# Altium schematic sheet FC20.SchDoc(FC20)
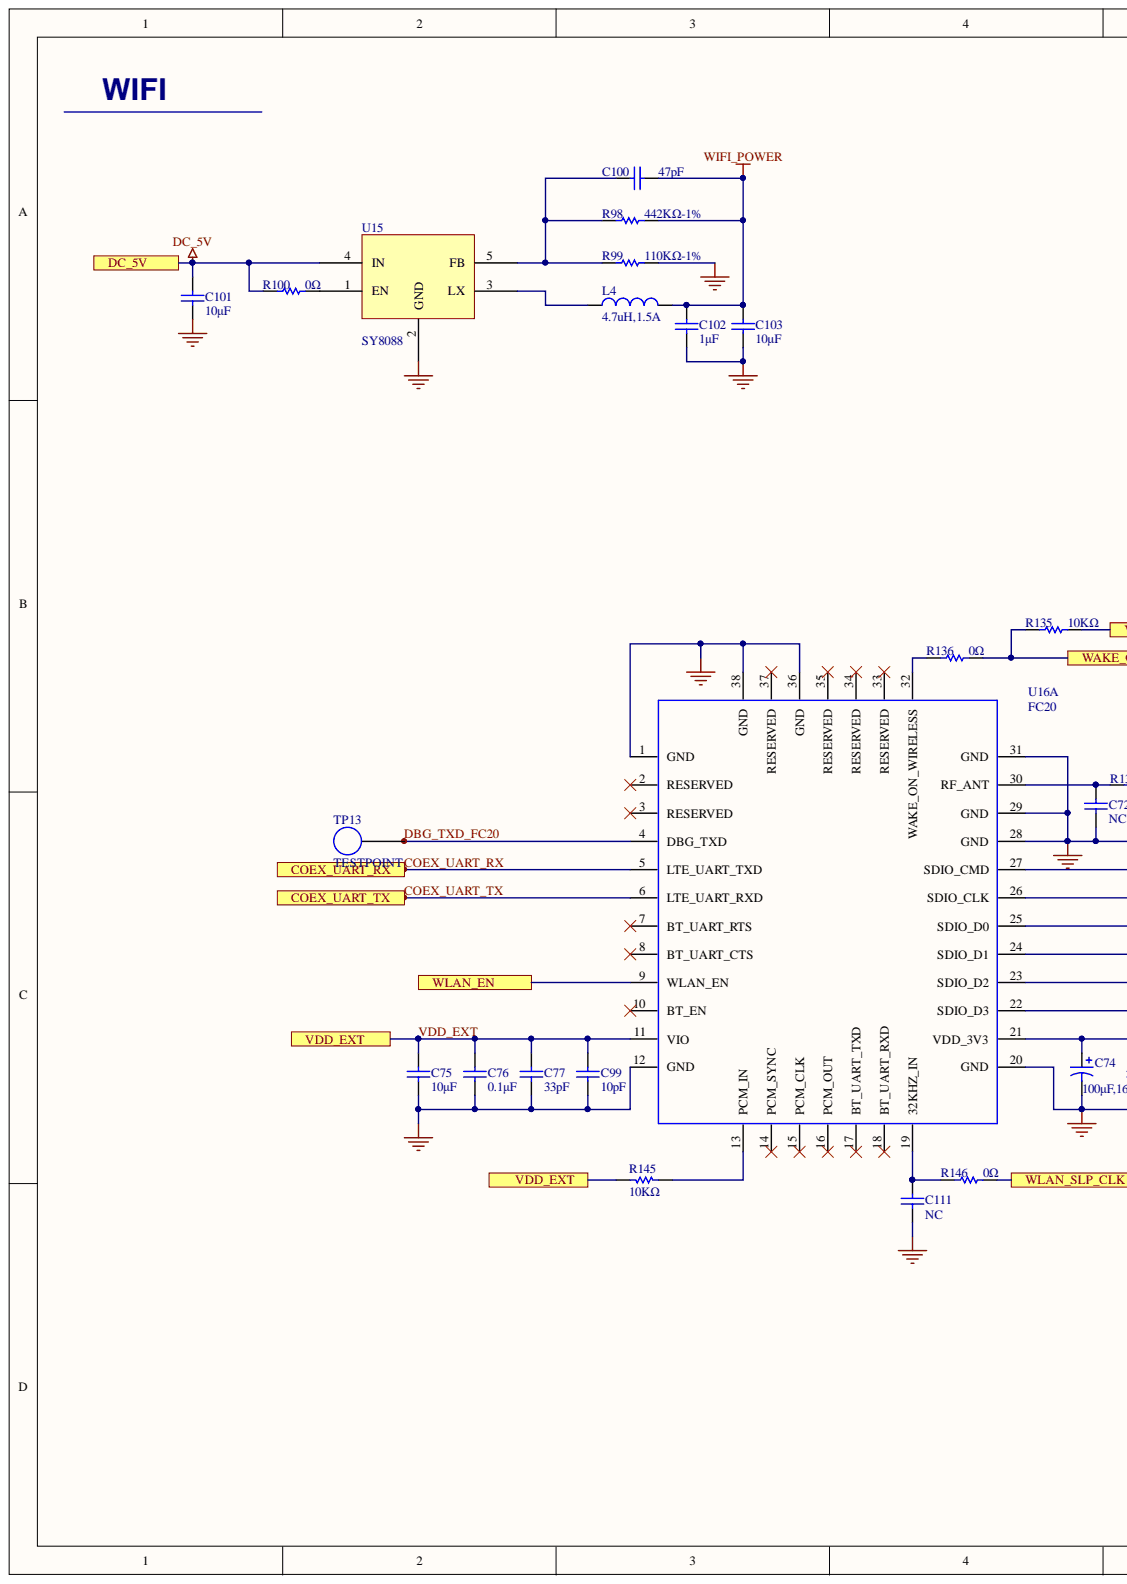
[diagram p0: FC20.SchDoc, left]
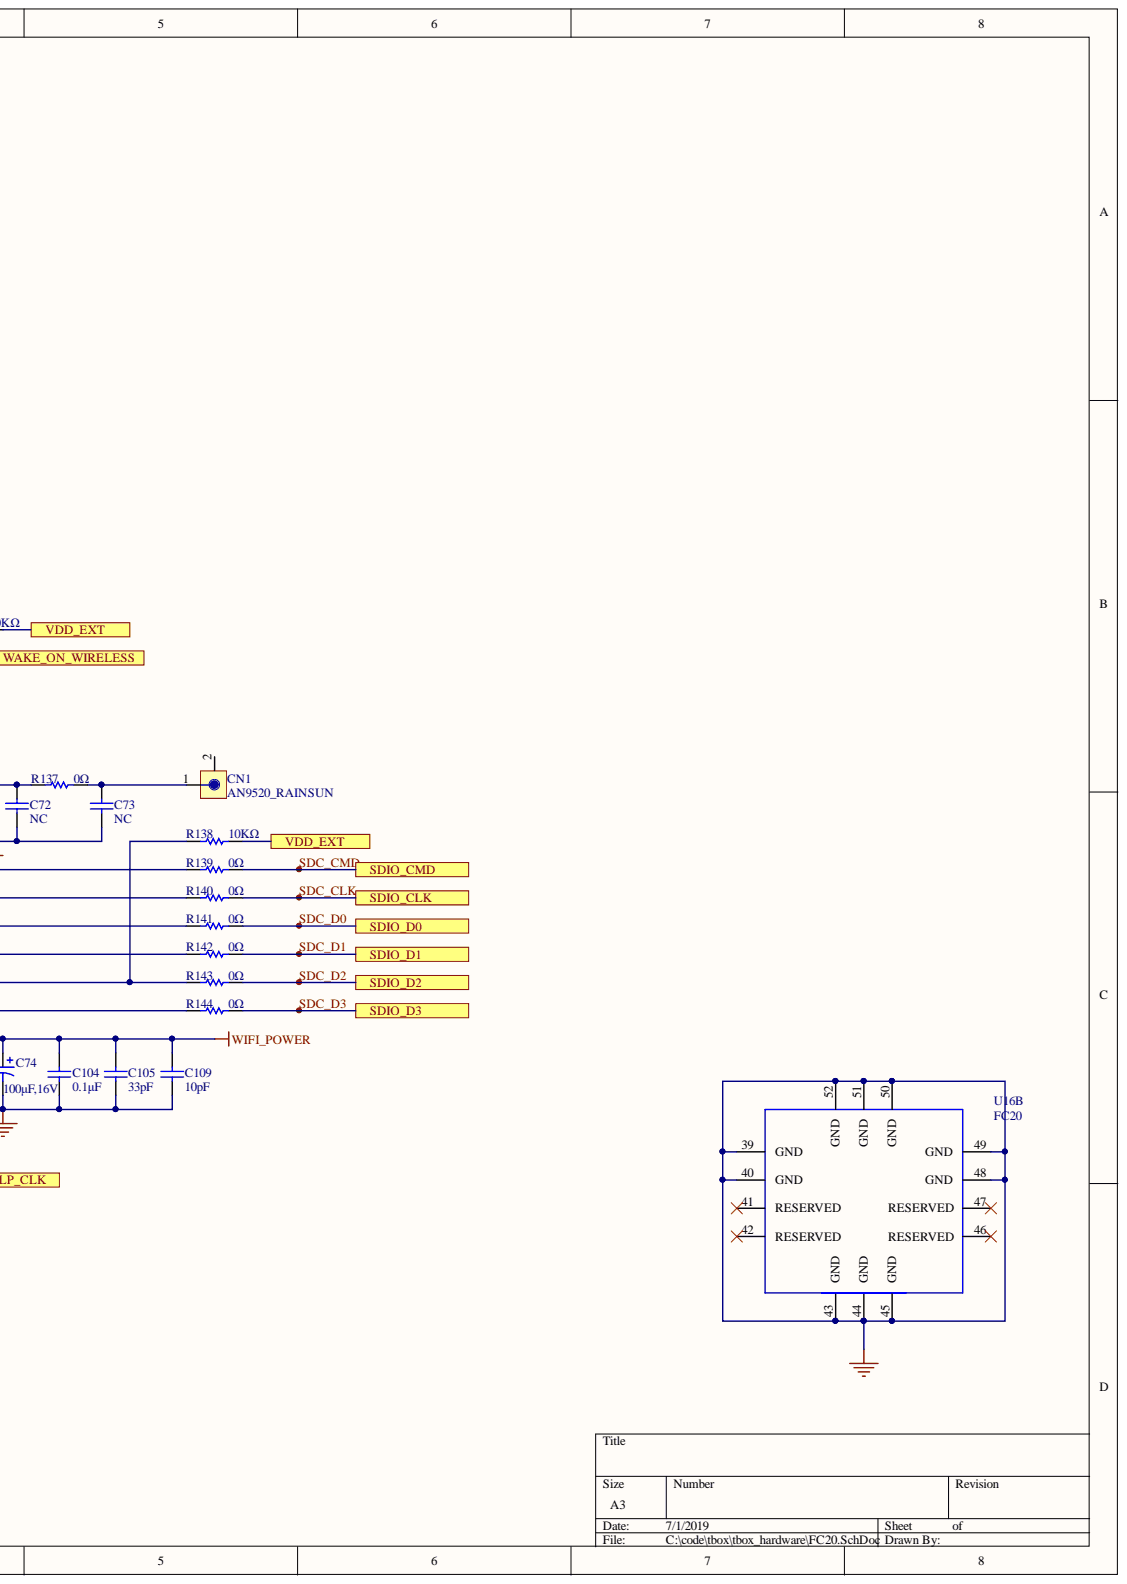
[diagram p1: FC20.SchDoc, right]

## Parts
C72  NC  [C0402]
C73  NC  [C0402]
C74  100μF,16V  [C1210]
C75  10μF  [C0805]
C76  0.1μF  [C0402]
C77  33pF  [C0402]
C99  10pF  [C0402]
C100  47pF  [C0402]
C101  10μF  [C0805]
C102  1μF  [C0402]
C103  10μF  [C0805]
C104  0.1μF  [C0402]
C105  33pF  [C0402]
C109  10pF  [C0402]
C111  NC  [C0402]
CN1  AN9520_RAINSUN  [ANT9mm*2mm]
L4  4.7uH,1.5A  [L-0420]
R98  442KΩ-1%  [R0402]
R99  110KΩ-1%  [R0402]
R100  0Ω  [R0402]
R135  10KΩ  [R0402]
R136  0Ω  [R0402]
R137  0Ω  [R0402]
R138  10KΩ  [R0402]
R139  0Ω  [R0402]
R140  0Ω  [R0402]
R141  0Ω  [R0402]
R142  0Ω  [R0402]
R143  0Ω  [R0402]
R144  0Ω  [R0402]
R145  10KΩ  [R0402]
R146  0Ω  [R0402]
TP13  TESTPOINT  [TP]
U15  SY8088  [SOT23-5]
U16  FC20  [FC20]  (parts A,B)

## Ports
COEX_UART_RX, COEX_UART_TX, DC_5V, SDIO_CLK, SDIO_CMD, SDIO_D0, SDIO_D1, SDIO_D2, SDIO_D3, VDD_EXT, WAKE_ON_WIRELESS, WLAN_EN, WLAN_SLP_CLK

## Nets
GND: C72.1 C73.1 C74.2 C75.1 C76.1 C77.1 C99.1 C101.1 C102.1 C103.1 C104.1 C105.1 C109.1 C111.1 R99.2 U15.2(GND) U16.1(GND) U16.12(GND) U16.20(GND) U16.28(GND) U16.29(GND) U16.31(GND) U16.36(GND) U16.38(GND) U16.39(GND) U16.40(GND) U16.43(GND) U16.44(GND) U16.45(GND) U16.48(GND) U16.49(GND) U16.50(GND) U16.51(GND) U16.52(GND)
WIFI_POWER: C74.1 C100.2 C102.2 C103.2 C104.2 C105.2 C109.2 L4.2 R98.2 U16.21(VDD_3V3)
COEX_UART_RX: U16.5(LTE_UART_TXD) ; port COEX_UART_RX
COEX_UART_TX: U16.6(LTE_UART_RXD) ; port COEX_UART_TX
DBG_TXD_FC20: TP13.1 U16.4(DBG_TXD)
DC_5V: C101.2 R100.1 U15.4(IN) ; port DC_5V
SDC_CLK: R140.1 ; port SDIO_CLK
SDC_CMD: R139.1 ; port SDIO_CMD
SDC_D0: R141.1 ; port SDIO_D0
SDC_D1: R142.1 ; port SDIO_D1
SDC_D2: R143.1 ; port SDIO_D2
SDC_D3: R144.1 ; port SDIO_D3
VDD_EXT: C75.2 C76.2 C77.2 C99.2 R135.1 R138.1 R145.1 U16.11(VIO) ; port VDD_EXT
WAKE_ON_WIRELESS: R135.2 R136.1 ; port WAKE_ON_WIRELESS
WLAN_EN: U16.9(WLAN_EN) ; port WLAN_EN
WLAN_SLP_CLK: R146.1 ; port WLAN_SLP_CLK
NetC72_2: C72.2 R137.2 U16.30(RF_ANT)
NetC73_2: C73.2 CN1.1 R137.1
NetC100_1: C100.1 R98.1 R99.1 U15.5(FB)
NetC111_2: C111.2 R146.2 U16.19(32KHZ_IN)
NetL4_1: L4.1 U15.3(LX)
NetR100_2: R100.2 U15.1(EN)
NetR136_2: R136.2 U16.32(WAKE_ON_WIRELESS)
NetR138_2: R138.2 R143.2 U16.23(SDIO_D2)
NetR139_2: R139.2 U16.27(SDIO_CMD)
NetR140_2: R140.2 U16.26(SDIO_CLK)
NetR141_2: R141.2 U16.25(SDIO_D0)
NetR142_2: R142.2 U16.24(SDIO_D1)
NetR144_2: R144.2 U16.22(SDIO_D3)
NetR145_2: R145.2 U16.13(PCM_IN)

Not connected: CN1 2; U16 2(RESERVED) 3(RESERVED) 7(BT_UART_RTS) 8(BT_UART_CTS) 10(BT_EN) 14(PCM_SYNC) 15(PCM_CLK) 16(PCM_OUT) 17(BT_UART_TXD) 18(BT_UART_RXD) 33(RESERVED) 34(RESERVED) 35(RESERVED) 37(RESERVED) 41(RESERVED) 42(RESERVED) 46(RESERVED) 47(RESERVED)

## Library data of the parts
C72 C73 C75-C77 C99-C105 C109 C111 = Cap: Capacitor; Simulation: CAP; Signal Integrity: Cap; Published: 8-Jun-2000; PackageReference: RAD-0.3; PackageDescription: Radial Cap, Thru-Hole; 2 Leads; 0.3 in Pin Spacing; Library Name: Miscellaneous Devices.SchLib; LatestRevisionNote: Re-released for DXP Platform.; LatestRevisionDate: 17-Jul-2002; Component Kind: Standard; Publisher: Altium Limited
  C72 C73 C111: Value: NC
  C75 C101 C103: Value: 10μF
  C76 C104: Value: 0.1μF
  C77 C105: Value: 33pF
  C99 C109: Value: 10pF
  C100: Value: 47pF
  C102: Value: 1μF
C74 = Cap Pol2: Polarized Capacitor (Axial); Value: 100μF,16V; Simulation: CAP; Signal Integrity: Cap Pol; Published: 8-Jun-2000; PackageReference: POLAR0.8; PackageDescription: Polarized Capacitor; 2 Leads; Library Name: Miscellaneous Devices.SchLib; LatestRevisionNote: Re-released for DXP Platform.; LatestRevisionDate: 17-Jul-2002; Component Kind: Standard; Publisher: Altium Limited
CN1 = ANT_CN: Bluetooth, 2.4 GHz WLAN,antenna; Library Name: Miscellaneous Devices.SchLib; Component Kind: Standard
L4 = Inductor: Code_IEC: 1005; Value: 4.7uH,1.5A; Simulation: INDUCTOR; Signal Integrity: Inductor; Published: 8-Jun-2000; PackageReference: 0402-A; PackageDescription: Chip Inductor; Library Name: Miscellaneous Devices.SchLib; LatestRevisionNote: IPC-7351 Footprint Added.; LatestRevisionDate: 29-May-2009; Description: Inductor; Component Kind: Standard; Code_JEITA: 0402; Publisher: Altium Limited
R98-R100 R135-R146 = Res1: Resistor; Simulation: RESISTOR; Signal Integrity: Res; Published: 8-Jun-2000; PackageReference: AXIAL-0.3; PackageDescription: Axial Device, Thru-Hole; 2 Leads; 0.3 in Pin Spacing; Library Name: Miscellaneous Devices.SchLib; LatestRevisionNote: Re-released for DXP Platform.; LatestRevisionDate: 17-Jul-2002; Component Kind: Standard; Publisher: Altium Limited
  R98: Value: 442KΩ-1%
  R99: Value: 110KΩ-1%
  R100 R136 R137 R139-R144 R146: Value: 0Ω
  R135 R138 R145: Value: 10KΩ
U15 = SY8088: High Efficiency 1.5MHz ,1A Synchronous Step Down Regulator; Library Name: Miscellaneous Devices.SchLib; Component Kind: Standard
TP13 = TestPoint: Library Name: Miscellaneous Devices.SchLib; Component Kind: Standard
U16 = FC20: Library Name: Miscellaneous Devices.SchLib; Component Kind: Standard
